# Altium schematic sheet Sheet2.SchDoc
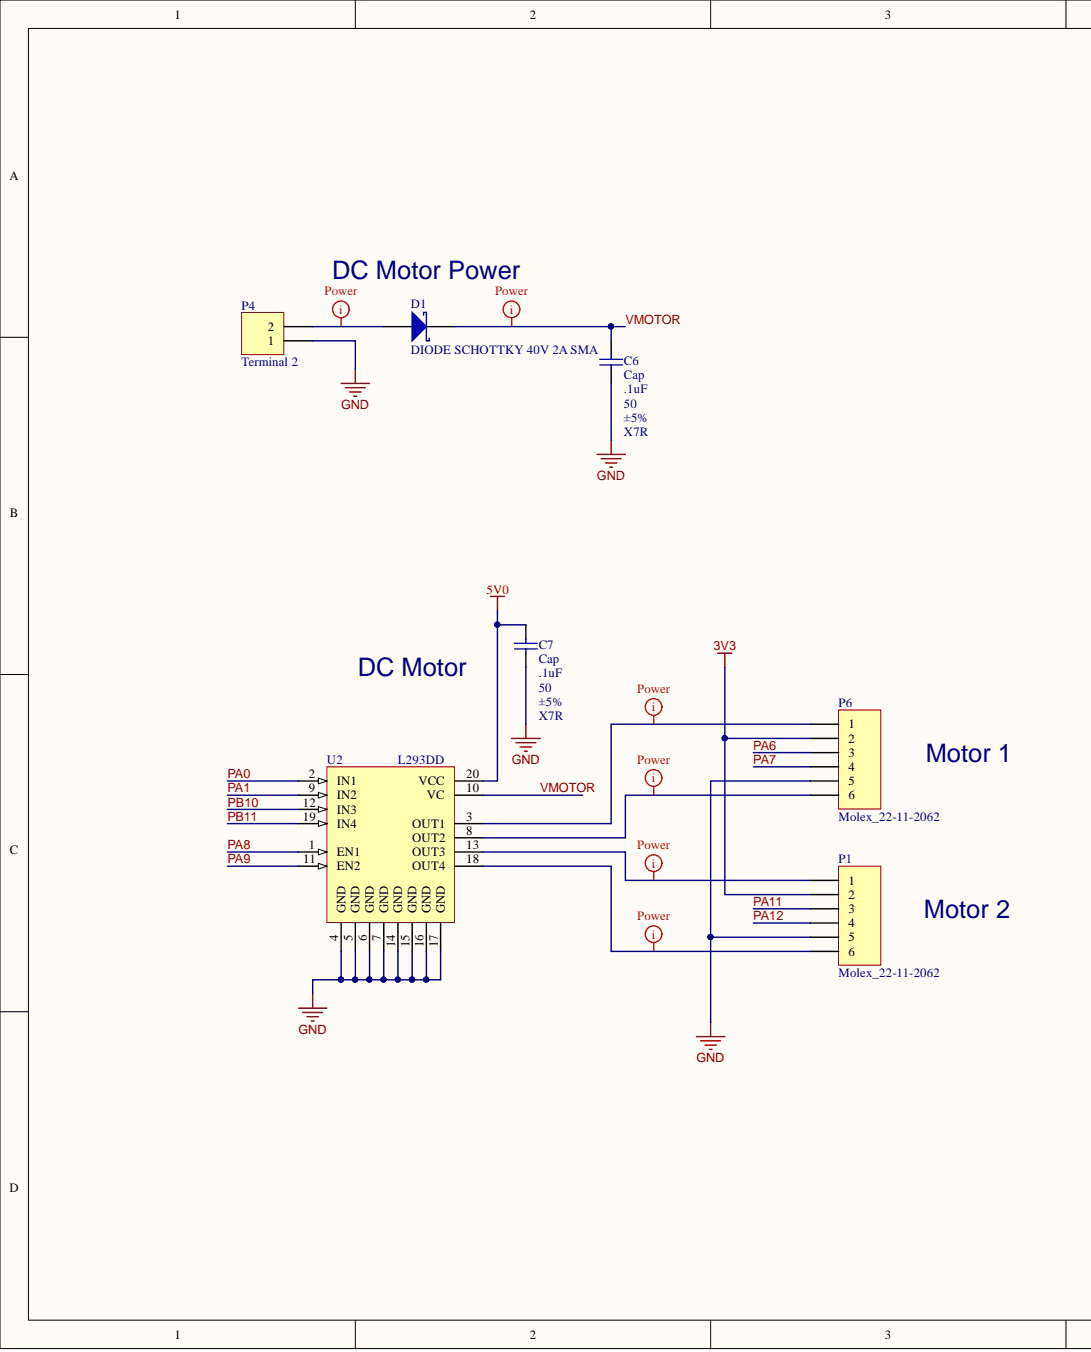
[diagram p0: Sheet2.SchDoc, left]
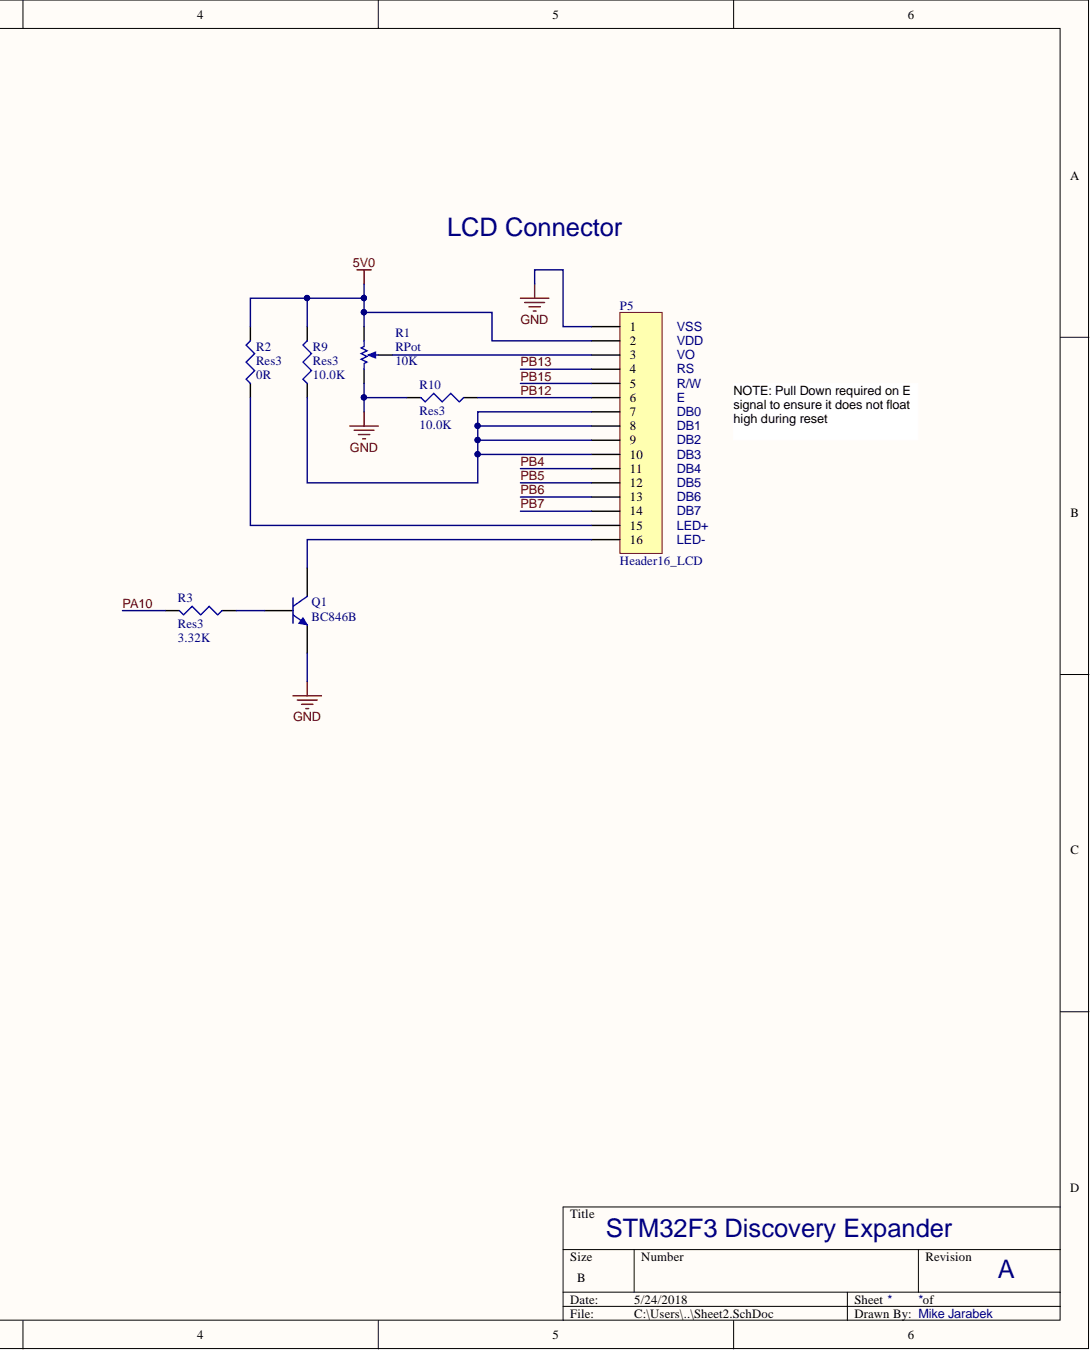
[diagram p1: Sheet2.SchDoc, right]

## Parts
C6  Cap  value .1uF  Tolerance: ±5%  [CAPC2012X09M]
C7  Cap  value .1uF  Tolerance: ±5%  [CAPC2012X09M]
D1  DIODE SCHOTTKY 40V 2A SMA  [DIOM4326X23M]
P1  Molex_22-11-2062  [MOLEX_22-11-2062]
P4  Terminal 2  [TYCO_282841_2]
P5  Header16_LCD  [HDR1X16_LCD]
P6  Molex_22-11-2062  [MOLEX_22-11-2062]
Q1  BC846B  [SOT95P230X110-3M]
R1  RPot  value 10K  [BOURNS_3386F]
R2  Res3  value 0R  [RESC2112X05M]
R3  Res3  value 3.32K  [RESC2112X05M]
R9  Res3  value 10.0K  [RESC2112X05M]
R10  Res3  value 10.0K  [RESC2112X05M]
U2  L293DD  [SO20_N]

## Nets
3V3: P1.2 P6.2
5V0: C7.2 P5.2 R1.1 R2.2 R9.2 U2.20(VCC)
GND: C6.1 C7.1 P1.5 P4.1 P5.1 P6.5 Q1.2 R1.3 R10.2 U2.4(GND) U2.5(GND) U2.6(GND) U2.7(GND) U2.14(GND) U2.15(GND) U2.16(GND) U2.17(GND)
PA0: U2.2(IN1)
PA1: U2.9(IN2)
PA6: P6.3
PA7: P6.4
PA8: U2.1(EN1)
PA9: U2.11(EN2)
PA10: R3.2
PA11: P1.3
PA12: P1.4
PB4: P5.11
PB5: P5.12
PB6: P5.13
PB7: P5.14
PB10: U2.12(IN3)
PB11: U2.19(IN4)
PB12: P5.6 R10.1
PB13: P5.4
PB15: P5.5
VMOTOR: C6.2 D1.1 U2.10(VC)
NetD1_2: D1.2 P4.2
NetP1_1: P1.1 U2.13(OUT3)
NetP1_6: P1.6 U2.18(OUT4)
NetP5_3: P5.3 R1.2
NetP5_7: P5.7 P5.8 P5.9 P5.10 R9.1
NetP5_15: P5.15 R2.1
NetP5_16: P5.16 Q1.3
NetP6_1: P6.1 U2.3(OUT1)
NetP6_6: P6.6 U2.8(OUT2)
NetQ1_1: Q1.1 R3.1

## Library data of the parts
C6 C7 = CAP-X7R-.1uF-50V-±5%: CAP CER .1uF 50v X7R 0805; MPN: C0805C104J5RACTU; PN: 399-1171-1-ND; WV: 50; Component Kind: Standard; Library Name: esd_library.IntLib; Name: CAP-X7R-.1uF-50V-±5%; Type: X7R; URL: http://www.digikey.ca/product-detail/en/C0805C104J5RACTU/399-1171-1-ND
D1 = DIODE SCHOTTKY 40V 2A SMA: Schottky Rectifier; MPN: B240A-13-F; PN: B240A-FDICT-ND; Component Kind: Standard; Library Name: esd_library.IntLib; URL: http://www.digikey.ca/product-detail/en/B240A-13-F/B240A-FDICT-ND
P1 P6 = Molex_22-11-2062: CONN HEADER 6POS .100 VERT GOLD; MPN: 0022112062; PN: WM2704-ND; Component Kind: Standard; Library Name: esd_library.IntLib; URL: http://www.digikey.ca/product-detail/en/0022112062/WM2704-ND
P4 = Terminal 2: Terminal, 2-Pin; MPN: 282841-2; PN: A98342-ND; Component Kind: Standard; LatestRevisionDate: 17-Jul-2002; LatestRevisionNote: Re-released for DXP Platform.; Library Name: esd_library.IntLib; Signal Integrity: Connector; URL: http://www.digikey.ca/product-detail/en/282841-2/A98342-ND/1136740; Publisher: Altium Limited
P5 = Header16_LCD: Header, 16-Pin Female; MPN: PPPC161LFBN-RC; PN: S7049-ND; Component Kind: Standard; Library Name: esd_library.IntLib; Signal Integrity: Connector; URL: http://www.digikey.ca/product-detail/en/PPPC161LFBN-RC/S7049-ND
Q1 = BC846B: TRANS NPN 65V 0.1A SOT23; MPN: BC846B,215; PN: 568-1632-1-ND; Component Kind: Standard; Library Name: esd_library.IntLib; URL: http://www.digikey.ca/product-detail/en/BC846B,215/568-1632-1-ND
R1 = RPot: POT 10K OHM THUMBWHEEL CERM ST; MPN: 3352T-1-103LF; PN: 3352T-103LF-ND; Set Position: 0.5; Sim Note: Enter the Resistance value and the set point into parameter fields.; Component Kind: Standard; LatestRevisionDate: 17-Jul-2002; LatestRevisionNote: Re-released for DXP Platform.; Library Name: esd_library.IntLib; PackageDescription: Variable Resistor, Thru-Hole; 3 Leads; PackageReference: VR5; Published: 8-Jun-2000; URL: http://www.digikey.ca/product-detail/en/3352T-1-103LF/3352T-103LF-ND; Publisher: Altium Limited
R2 = Resistor_0R_Jumper: RES SMD 0 OHM 5% 1/8W 0805; MPN: CRCW08050000Z0EA; PN: 541-0.0ACT-ND; Component Kind: Standard; Library Name: esd_library.IntLib; Name: Resistor_0R_Jumper; URL: http://www.digikey.ca/product-detail/en/CRCW08050000Z0EA/541-0.0ACT-ND
R3 = Resistor_3.32K: RES SMD 3.32K OHM 1% 1/8W 0805; MPN: CRCW08053K32FKEA; PN: 541-3.32KCCT-ND; Component Kind: Standard; Library Name: esd_library.IntLib; Name: Resistor_3.32K; URL: http://www.digikey.ca/product-detail/en/CRCW08053K32FKEA/541-3.32KCCT-ND
R9 R10 = Resistor_10.0K: RES SMD 10.0K OHM 1% 1/8W 0805; MPN: CRCW080510K0FKEA; PN: 541-10.0KCCT-ND; Component Kind: Standard; Library Name: esd_library.IntLib; Name: Resistor_10.0K; URL: http://www.digikey.ca/product-detail/en/CRCW080510K0FKEA/541-10.0KCCT-ND
U2 = L293DD: IC MOTOR DRIVER PAR 20-SOIC; MPN: L293DD013TR; PN: 497-2937-1-ND; Code_IPC: SOIC127P1030-20; Code_JEDEC: MS-013-AC; Component Kind: Standard; ComponentLink1Description: Manufacturer Link; ComponentLink1URL: http://www.st.com/; ComponentLink2Description: Datasheet; ComponentLink2URL: http://www.st.com/stonline/products/literature/ds/1330/l293d.pdf; DatasheetVersion: Jun-1996; LatestRevisionDate: 13-Apr-2006; LatestRevisionNote: IPC-7351 Footprint Added.; Library Name: esd_library.IntLib; PackageDescription: 20-Pin Plastic Small Outline Integrated Circuit 1.27 mm Pitch; PackageReference: SO20; PackageVersion: Jul-2001; PCB3D: SO20; Published: 8-Jun-2000; URL: http://www.digikey.ca/product-detail/en/L293DD013TR/497-2937-1-ND; Publisher: Altium Limited
